# Altium schematic sheet Main.SchDoc
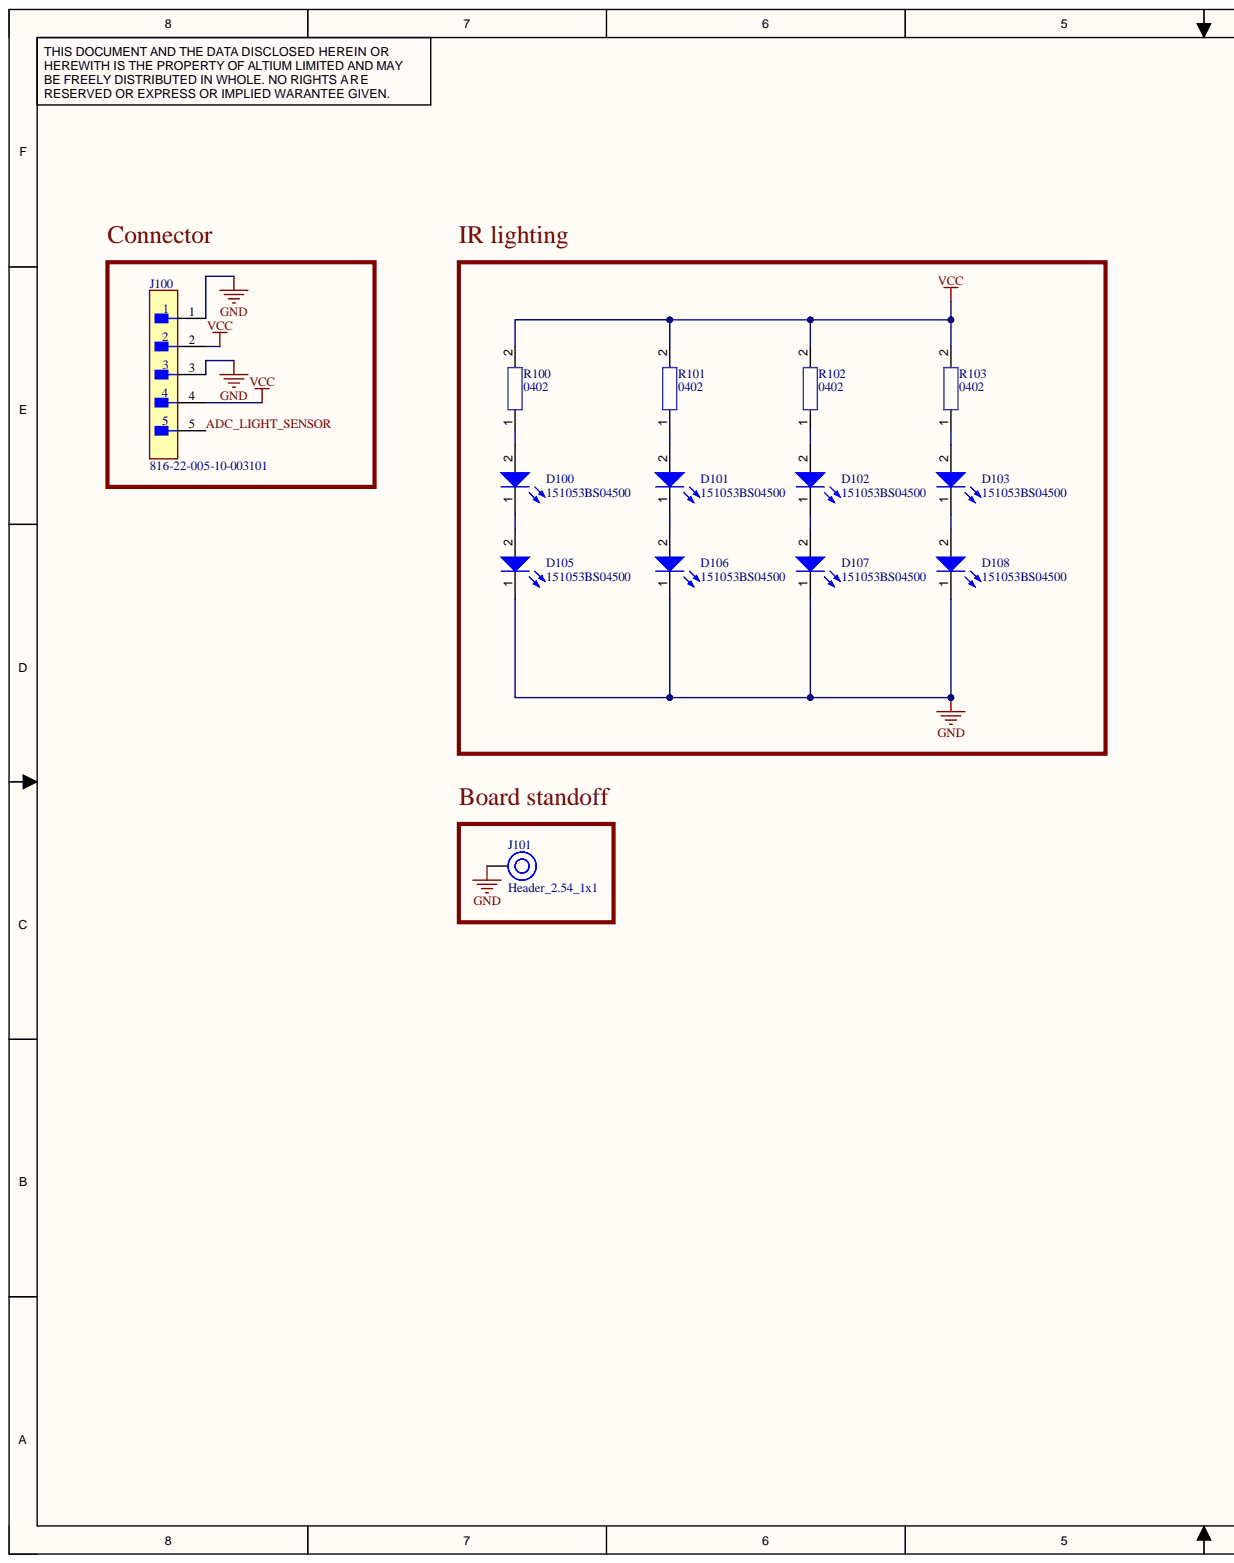
[diagram p0: Main.SchDoc, left]
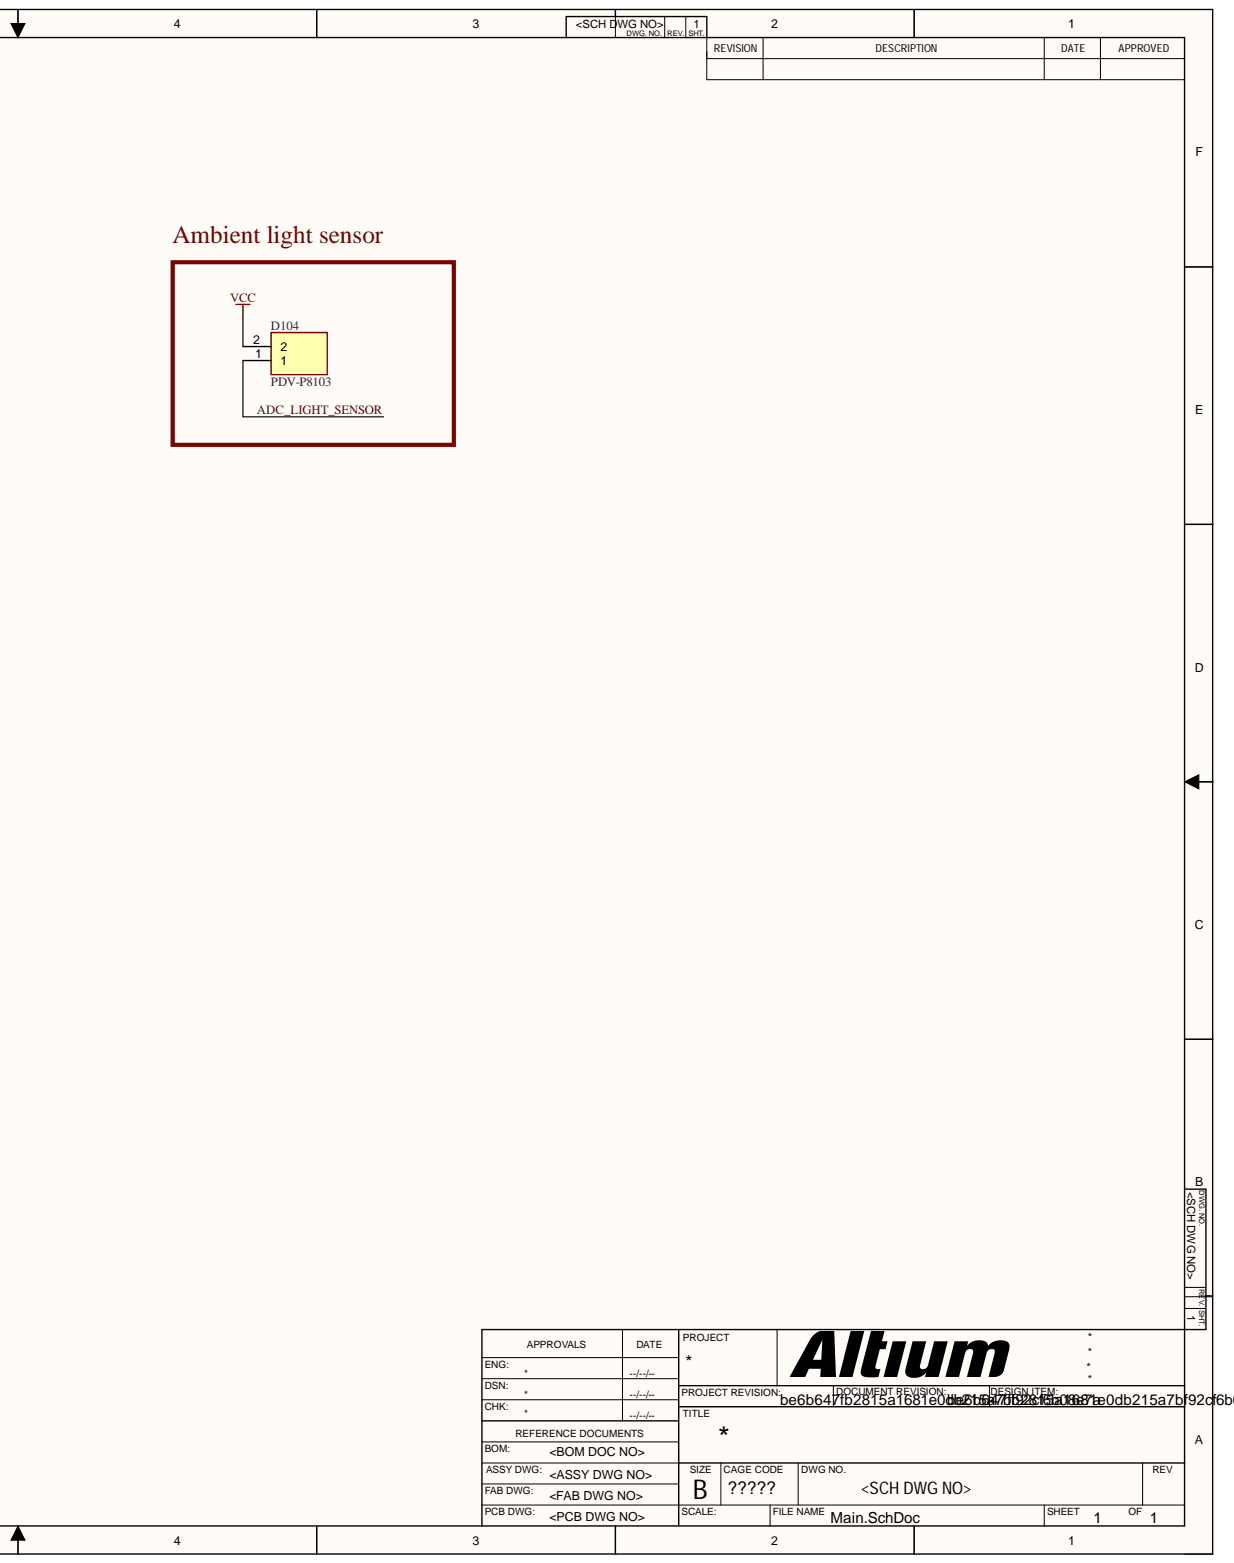
[diagram p1: Main.SchDoc, right]

## Parts
D100  151053BS04500  [WL-TMRW_D5.8H11.7]
D101  151053BS04500  [WL-TMRW_D5.8H11.7]
D102  151053BS04500  [WL-TMRW_D5.8H11.7]
D103  151053BS04500  [WL-TMRW_D5.8H11.7]
D104  PDV-P8103  [PDVP8103]
D105  151053BS04500  [WL-TMRW_D5.8H11.7]
D106  151053BS04500  [WL-TMRW_D5.8H11.7]
D107  151053BS04500  [WL-TMRW_D5.8H11.7]
D108  151053BS04500  [WL-TMRW_D5.8H11.7]
J100  816-22-005-10-003101  [FP-816-22-005-10-003101-MFG]
J101  Header_2.54_1x1  [HEADER_2.54_1x1]
R100  0402  [0402_footprint]
R101  0402  [0402_footprint]
R102  0402  [0402_footprint]
R103  0402  [0402_footprint]

## Nets
GND: D105.1 D106.1 D107.1 D108.1 J100.1 J100.3 J101.0
VCC: D104.2 J100.2 J100.4 R100.2 R101.2 R102.2 R103.2
ADC_LIGHT_SENSOR: D104.1 J100.5
NetD100_1: D100.1 D105.2
NetD100_2: D100.2 R100.1
NetD101_1: D101.1 D106.2
NetD101_2: D101.2 R101.1
NetD102_1: D102.1 D107.2
NetD102_2: D102.2 R102.1
NetD103_1: D103.1 D108.2
NetD103_2: D103.2 R103.1

## Library data of the parts
D100-D102 D105-D108 = CMP-1489-00006-2: NexarPartId: 29717816; Color: Blue; Dominant Wavelength: 465nm; Forward Current: 100mA; Forward Voltage: 2.7V; Lens Size: 5mm; Lens Style: Circular, Clear, Round; Luminous Intensity: 2cd; Max Reverse Voltage (DC): 5V; Peak Wavelength: 461nm; Test Current: 20mA; Viewing Angle: 45°; Case/Package: Radial; Component Kind: Standard; ComponentLink1Description: Buy; Height: 8.6mm; Length: 5mm; Library Name: Altium Content Vault; Max Operating Temperature: 85°C; Min Operating Temperature: -40°C; Mount: Through Hole; Packaging: Bulk; REACH SVHC: Unknown; RoHS: Compliant; Wavelength: 465nm; Width: 5mm
  D100 D101 D105 D106: ComponentLink1URL: https://www.mouser.lt/ProductDetail/Vishay-Semiconductors/TSAL6400?qs=oSAwVt7aKTHCOCv1ythi7g%3D%3D
  D102 D107 D108: ComponentLink1URL: https://www.mouser.lt/ProductDetail/Vishay-Semiconductors/TSHF5210?qs=%2Fjqivxn91cfAnyW9i5Zlpg%3D%3D
D103 = CMP-1489-00006-2: https://www.lemona.lt/sviesos-diodas-o5mm-ir-skaidrus-30.html; NexarPartId: 29717816; Color: Blue; Dominant Wavelength: 465nm; Forward Current: 100mA; Forward Voltage: 2.7V; Lens Size: 5mm; Lens Style: Circular, Clear, Round; Luminous Intensity: 2cd; Max Reverse Voltage (DC): 5V; Peak Wavelength: 461nm; Test Current: 20mA; Viewing Angle: 45°; Case/Package: Radial; Component Kind: Standard; ComponentLink1Description: Buy; ComponentLink1URL: https://www.mouser.lt/ProductDetail/Vishay-Semiconductors/TSHF5210?qs=%2Fjqivxn91cfAnyW9i5Zlpg%3D%3D; Height: 8.6mm; Length: 5mm; Library Name: Altium Content Vault; Max Operating Temperature: 85°C; Min Operating Temperature: -40°C; Mount: Through Hole; Packaging: Bulk; REACH SVHC: Unknown; RoHS: Compliant; Wavelength: 465nm; Width: 5mm
D104 = PDV-P8103: Manufacturer_Name: ADVANCED PHOTONIX; Component Kind: Standard; ComponentLink1Description: Buy; ComponentLink1URL: https://www.mouser.lt/ProductDetail/Advanced-Photonix/PDV-P8103?qs=tlsG%2FOw5FFiMv0vIkPUhuA%3D%3D; Datasheet Link: https://media.digikey.com/pdf/Data%20Sheets/Photonic%20Detetectors%20Inc%20PDFs/PDV-P8103.pdf; Height: 2.38mm; Manufacturer_Part_Number: PDV-P8103
J100 = CMP-07791-000028-1: CONN SPRING PISTON 5POS PCB; Current Rating: 2A; Insulation Resistance: 10GR; Number of Contacts: 5; Pitch: 2.54mm; Voltage Rating: 150V; Component Kind: Standard; Contact Gender: Male; Contact Material: Copper Alloy; Contact Plating: Gold; Flammability Rating: UL94 V-0; Housing Material: Thermoplastic; Library Name: Altium Content Vault; Material: Copper Alloy; Mount: Through Hole; Number of Positions: 5; Number of Rows: 1; Package Quantity: 1; Packaging: Bulk; RoHS Compliant: Yes; Series: 816; Termination: Through Hole
R100-R103 = Resistor_0402: 0402 resistor; Component Kind: Standard; Library Name: Schlib1.SchLib
J101 = Header_2.54_1x1: Component Kind: Standard; Library Name: Schlib1.SchLib
